# Altium schematic sheet CM4_HDMI.SchDoc(CM4_HDMI)
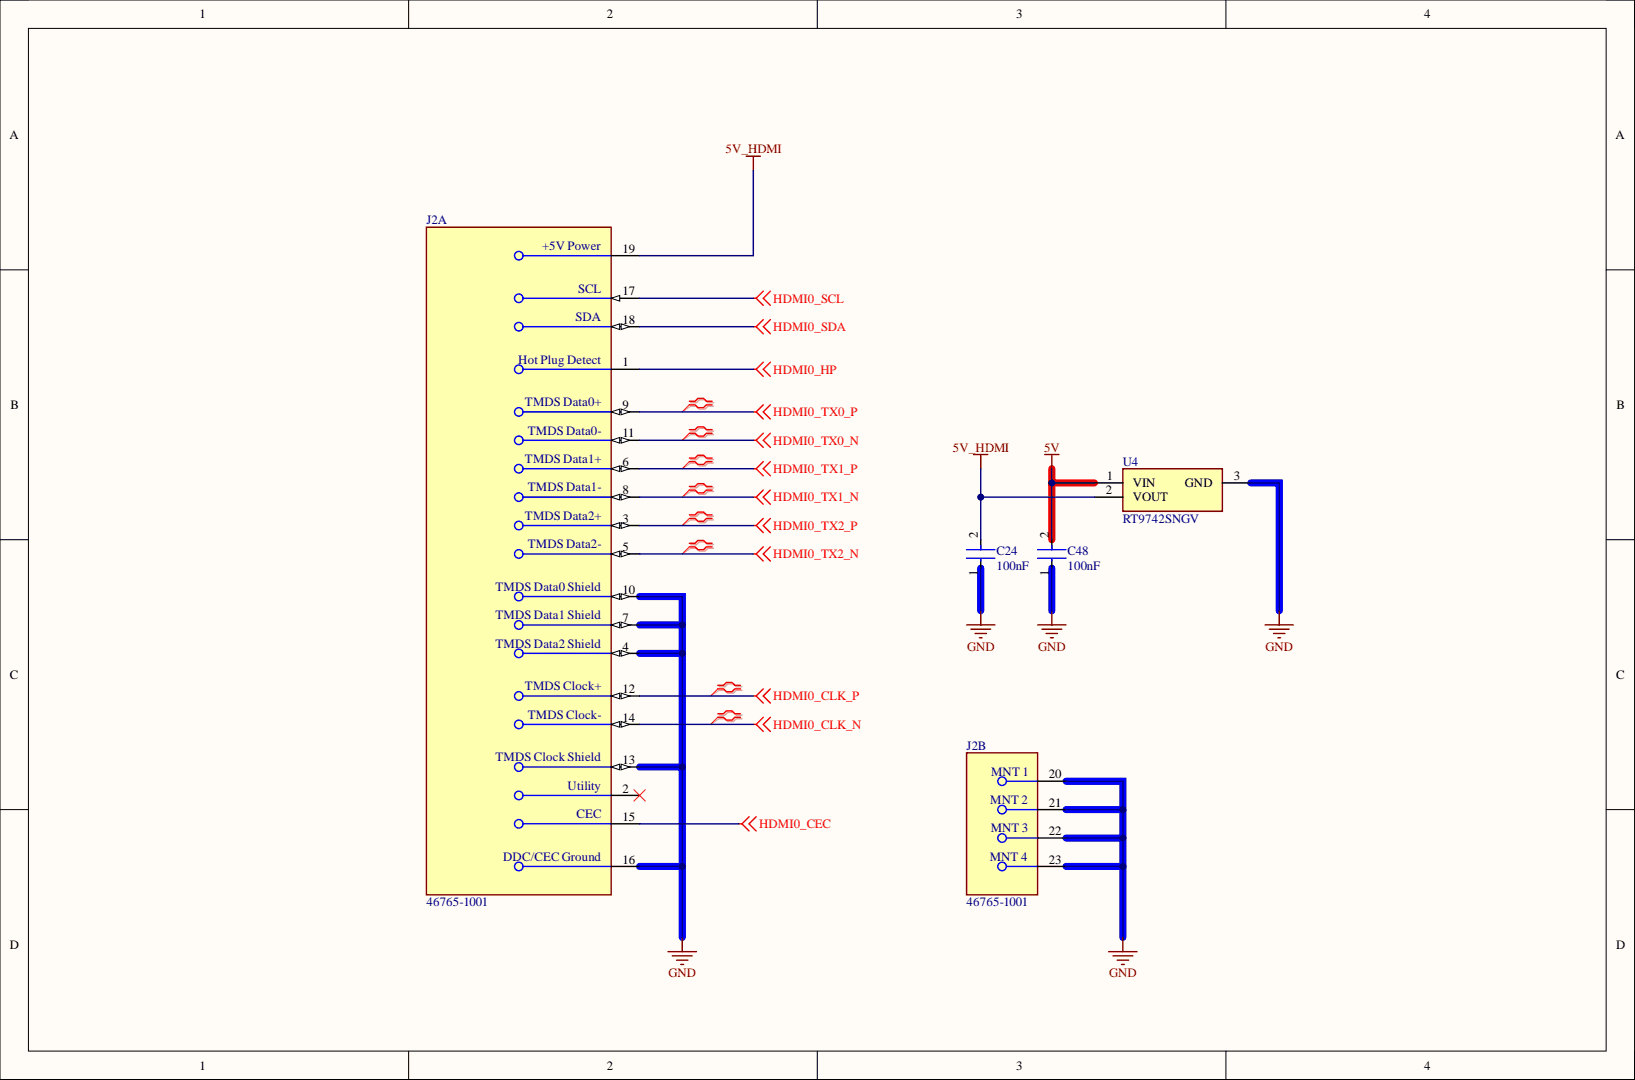
[diagram p0: CM4_HDMI.SchDoc]

## Parts
C24  100nF  [FP-GRM15-MFG]
C48  100nF  [FP-GRM15-MFG]
J2  46765-1001  [FP-46765-1001-MFG]  (parts A,B)
U4  RT9742SNGV  [SOT95P279X130-3N]

## Nets
5V: C48.2 U4.1
5V_HDMI: C24.2 J2.19 U4.2
GND: C24.1 C48.1 J2.4 J2.7 J2.10 J2.13 J2.16 J2.20 J2.21 J2.22 J2.23 U4.3
HDMI0_CEC: J2.15
HDMI0_CLK_N: J2.14
HDMI0_CLK_P: J2.12
HDMI0_HP: J2.1
HDMI0_SCL: J2.17
HDMI0_SDA: J2.18
HDMI0_TX0_N: J2.11
HDMI0_TX0_P: J2.9
HDMI0_TX1_N: J2.8
HDMI0_TX1_P: J2.6
HDMI0_TX2_N: J2.5
HDMI0_TX2_P: J2.3

Not connected: J2 2

## Library data of the parts
C24 C48 = CMP-2100-03641-2: CAP CER 0.1UF 16V X7R 0402; Manufacturer: Murata; Manufacturer Part Number: GRM155R71C104KA88D; Capacitance: 0.10μF; Material: Ceramic; Standoff Height: 0mm; Tolerance: 10%; Value: 0.10μF; Voltage Rating: 16Vdc; Category: Cap; Component Kind: Standard; ComponentLink2Description: Datasheet; ComponentLink2URL: https://search.murata.co.jp/Ceramy/image/img/A01X/G101/ENG/GRM155R71H471KA01-01A.pdf; Device Class L1: Passive Components; Device Class L2: Capacitors; Device Class L3: Ceramic Capacitors; Digikey Description: CAP CER 0.1UF 16V X7R 0402; Height: 0.55mm; Ipc Land Pattern Name: CAPC10050X219; Lead Free: yes; Library Name: Altium Content Vault; Mouser Description: 0402 0.1 uF 16V ±10 % Tolerance X7R SMT Multilayer Ceramic Capacitor; Octopart: https://octopart.com/grm155r71c104ka88d-murata-196880; Package: GRM15; RoHS: TRUE; Temperature: -55°C to +125°C; Temperature Characteristic: X7R; Temperature Range High: +125°C; Temperature Range Low: -55°C
J2 = CMP-04768-000007-1: CONN RCP MICRO HDMI 19POS SMD RA; Body Material: Plastic; Current Rating: 800mA; Gender: Receptacle; Number of Contacts: 19; Number of Ports: 1; Pitch: 0.4mm; Voltage Rating: 30V; Case/Package: Metal; Component Kind: Standard; Connector Type: HDMI; Contact Material: Copper Alloy; Contact Plating: Gold; Depth: 7.5mm; Flammability Rating: UL94 V-0; Housing Material: Polymer; Length: 6.5mm; Library Name: Altium Content Vault; Max Current Rating: 800mA; Max Operating Temperature: 85°C; Min Operating Temperature: -30°C; Mount: Surface Mount; Number of Positions: 19; Number of Terminals/Pins: 19; Orientation: Right Angle; Package Quantity: 1000; Packaging: Tape and Reel; RoHS Compliant: Yes; Series: 46765; Termination: Solder; Weight: 0.0109oz; Width: 7mm
U4 = RT9742SNGV: Integrated Circuit; Manufacturer_Name: RICHTEK; Component Kind: Standard; Datasheet Link: https://datasheet.lcsc.com/szlcsc/Richtek-Tech-RT9742ANGJ5F_C147955.pdf; Height: 1.295mm; Manufacturer_Part_Number: RT9742SNGV
